# Altium schematic sheet [10] - CONNECTORs.SchDoc(CONNECTORS)
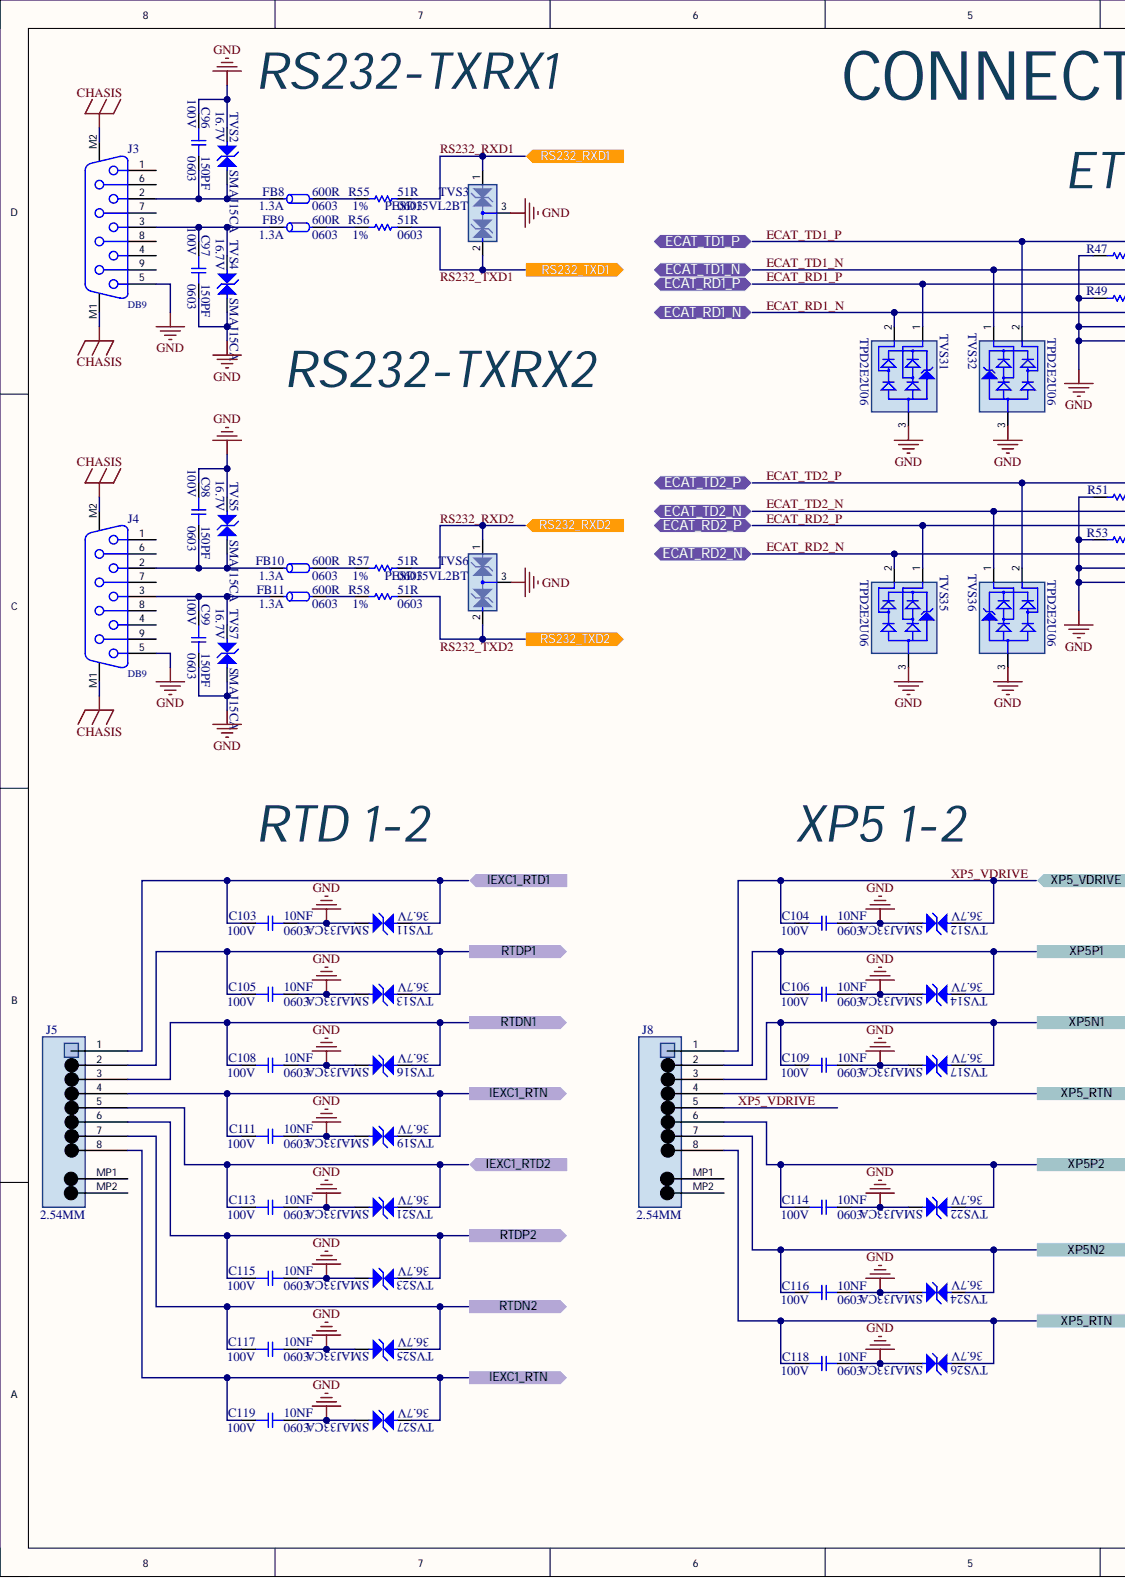
[diagram p0: [10] - CONNECTORs.SchDoc, left]
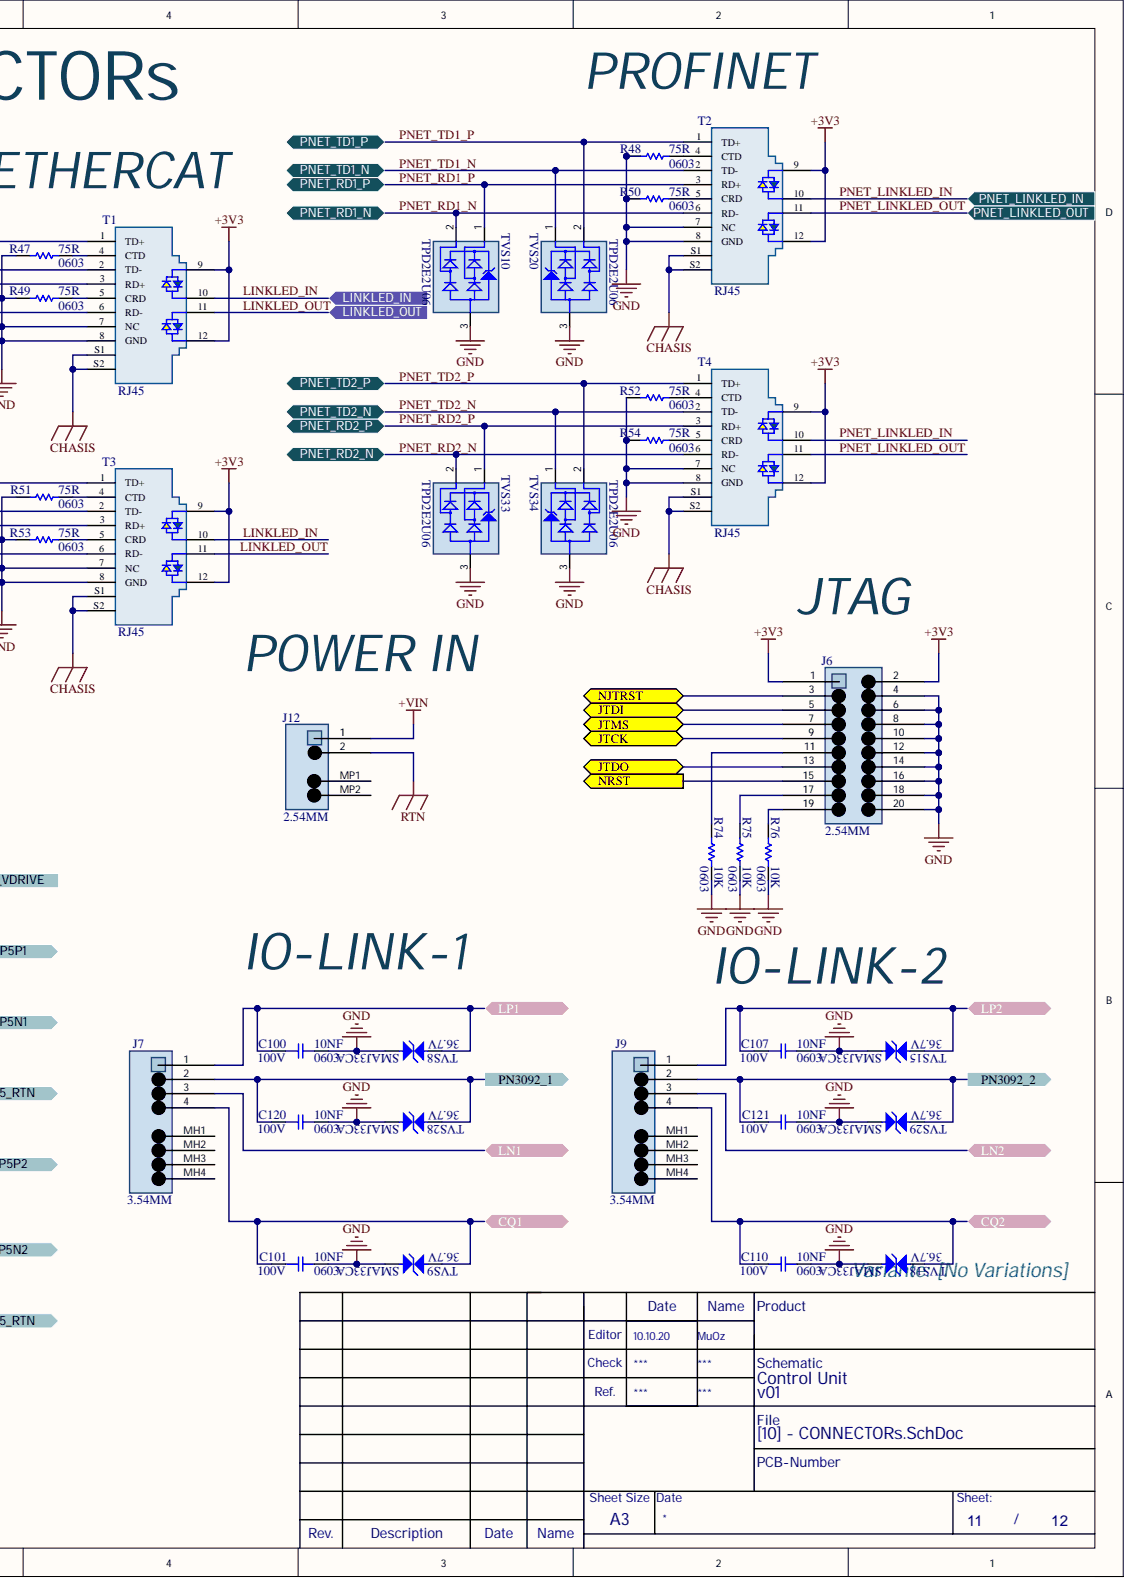
[diagram p1: [10] - CONNECTORs.SchDoc, right]

## Parts
C96  150PF  Case Code (Imperial): 0603  Voltage Rating: 100V  [C-0603]
C97  150PF  Case Code (Imperial): 0603  Voltage Rating: 100V  [C-0603]
C98  150PF  Case Code (Imperial): 0603  Voltage Rating: 100V  [C-0603]
C99  150PF  Case Code (Imperial): 0603  Voltage Rating: 100V  [C-0603]
C100  10NF  Case Code (Imperial): 0603  Voltage Rating: 100V  [C-0603]
C101  10NF  Case Code (Imperial): 0603  Voltage Rating: 100V  [C-0603]
C103  10NF  Case Code (Imperial): 0603  Voltage Rating: 100V  [C-0603]
C104  10NF  Case Code (Imperial): 0603  Voltage Rating: 100V  [C-0603]
C105  10NF  Case Code (Imperial): 0603  Voltage Rating: 100V  [C-0603]
C106  10NF  Case Code (Imperial): 0603  Voltage Rating: 100V  [C-0603]
C107  10NF  Case Code (Imperial): 0603  Voltage Rating: 100V  [C-0603]
C108  10NF  Case Code (Imperial): 0603  Voltage Rating: 100V  [C-0603]
C109  10NF  Case Code (Imperial): 0603  Voltage Rating: 100V  [C-0603]
C110  10NF  Case Code (Imperial): 0603  Voltage Rating: 100V  [C-0603]
C111  10NF  Case Code (Imperial): 0603  Voltage Rating: 100V  [C-0603]
C113  10NF  Case Code (Imperial): 0603  Voltage Rating: 100V  [C-0603]
C114  10NF  Case Code (Imperial): 0603  Voltage Rating: 100V  [C-0603]
C115  10NF  Case Code (Imperial): 0603  Voltage Rating: 100V  [C-0603]
C116  10NF  Case Code (Imperial): 0603  Voltage Rating: 100V  [C-0603]
C117  10NF  Case Code (Imperial): 0603  Voltage Rating: 100V  [C-0603]
C118  10NF  Case Code (Imperial): 0603  Voltage Rating: 100V  [C-0603]
C119  10NF  Case Code (Imperial): 0603  Voltage Rating: 100V  [C-0603]
C120  10NF  Case Code (Imperial): 0603  Voltage Rating: 100V  [C-0603]
C121  10NF  Case Code (Imperial): 0603  Voltage Rating: 100V  [C-0603]
FB8  600R  Case Code (Imperial): 0603  Current Rating: 1.3A  [FB-0603]
FB9  600R  Case Code (Imperial): 0603  Current Rating: 1.3A  [FB-0603]
FB10  600R  Case Code (Imperial): 0603  Current Rating: 1.3A  [FB-0603]
FB11  600R  Case Code (Imperial): 0603  Current Rating: 1.3A  [FB-0603]
J3  DB9  [CON-DB9-618009211821]
J4  DB9  [CON-DB9-618009211821]
J5  2.54MM  [CON-HARTING-8POS-2.54MM]
J6  2.54MM  [CON-SWD-20 POSITION]
J7  3.54MM  [CON-4PIN-IOLINK]
J8  2.54MM  [CON-HARTING-8POS-2.54MM]
J9  3.54MM  [CON-4PIN-IOLINK]
J12  2.54MM  [CON-HARTING-2POS-2.54MM]
R47  75R  Case Code (Imperial): 0603  [R-0603]
R48  75R  Case Code (Imperial): 0603  [R-0603]
R49  75R  Case Code (Imperial): 0603  [R-0603]
R50  75R  Case Code (Imperial): 0603  [R-0603]
R51  75R  Case Code (Imperial): 0603  [R-0603]
R52  75R  Case Code (Imperial): 0603  [R-0603]
R53  75R  Case Code (Imperial): 0603  [R-0603]
R54  75R  Case Code (Imperial): 0603  [R-0603]
R55  51R  Case Code (Imperial): 0603  Tolerance: 1%  [R-0603]
R56  51R  Case Code (Imperial): 0603  Tolerance: 1%  [R-0603]
R57  51R  Case Code (Imperial): 0603  Tolerance: 1%  [R-0603]
R58  51R  Case Code (Imperial): 0603  Tolerance: 1%  [R-0603]
R74  10K  Case Code (Imperial): 0603  [R-0603]
R75  10K  Case Code (Imperial): 0603  [R-0603]
R76  10K  Case Code (Imperial): 0603  [R-0603]
T1  RJ45  [CON-RJ45-74980104400]
T2  RJ45  [CON-RJ45-74980104400]
T3  RJ45  [CON-RJ45-74980104400]
T4  RJ45  [CON-RJ45-74980104400]
TVS2  SMAJ15CA  Breakdown Voltage: 16.7V  [D-SMA-DO214AC-CA]
TVS3  PESD15VL2BT  [SOT23-3]
TVS4  SMAJ15CA  Breakdown Voltage: 16.7V  [D-SMA-DO214AC-CA]
TVS5  SMAJ15CA  Breakdown Voltage: 16.7V  [D-SMA-DO214AC-CA]
TVS6  PESD15VL2BT  [SOT23-3]
TVS7  SMAJ15CA  Breakdown Voltage: 16.7V  [D-SMA-DO214AC-CA]
TVS8  SMAJ33CA  Breakdown Voltage: 36.7V  [D-SMA-DO214AC-CA]
TVS9  SMAJ33CA  Breakdown Voltage: 36.7V  [D-SMA-DO214AC-CA]
TVS10  TPD2E2U06  [SOT323-3]
TVS11  SMAJ33CA  Breakdown Voltage: 36.7V  [D-SMA-DO214AC-CA]
TVS12  SMAJ33CA  Breakdown Voltage: 36.7V  [D-SMA-DO214AC-CA]
TVS13  SMAJ33CA  Breakdown Voltage: 36.7V  [D-SMA-DO214AC-CA]
TVS14  SMAJ33CA  Breakdown Voltage: 36.7V  [D-SMA-DO214AC-CA]
TVS15  SMAJ33CA  Breakdown Voltage: 36.7V  [D-SMA-DO214AC-CA]
TVS16  SMAJ33CA  Breakdown Voltage: 36.7V  [D-SMA-DO214AC-CA]
TVS17  SMAJ33CA  Breakdown Voltage: 36.7V  [D-SMA-DO214AC-CA]
TVS18  SMAJ33CA  Breakdown Voltage: 36.7V  [D-SMA-DO214AC-CA]
TVS19  SMAJ33CA  Breakdown Voltage: 36.7V  [D-SMA-DO214AC-CA]
TVS20  TPD2E2U06  [SOT323-3]
TVS21  SMAJ33CA  Breakdown Voltage: 36.7V  [D-SMA-DO214AC-CA]
TVS22  SMAJ33CA  Breakdown Voltage: 36.7V  [D-SMA-DO214AC-CA]
TVS23  SMAJ33CA  Breakdown Voltage: 36.7V  [D-SMA-DO214AC-CA]
TVS24  SMAJ33CA  Breakdown Voltage: 36.7V  [D-SMA-DO214AC-CA]
TVS25  SMAJ33CA  Breakdown Voltage: 36.7V  [D-SMA-DO214AC-CA]
TVS26  SMAJ33CA  Breakdown Voltage: 36.7V  [D-SMA-DO214AC-CA]
TVS27  SMAJ33CA  Breakdown Voltage: 36.7V  [D-SMA-DO214AC-CA]
TVS28  SMAJ33CA  Breakdown Voltage: 36.7V  [D-SMA-DO214AC-CA]
TVS29  SMAJ33CA  Breakdown Voltage: 36.7V  [D-SMA-DO214AC-CA]
TVS31  TPD2E2U06  [SOT323-3]
TVS32  TPD2E2U06  [SOT323-3]
TVS33  TPD2E2U06  [SOT323-3]
TVS34  TPD2E2U06  [SOT323-3]
TVS35  TPD2E2U06  [SOT323-3]
TVS36  TPD2E2U06  [SOT323-3]

## Ports
CQ1, CQ2, ECAT_RD1_N, ECAT_RD1_P, ECAT_RD2_N, ECAT_RD2_P, ECAT_TD1_N, ECAT_TD1_P, ECAT_TD2_N, ECAT_TD2_P, IEXC1_RTD1, IEXC1_RTD2, IEXC1_RTN, JTCK, JTDI, JTDO, JTMS, LINKLED_IN, LINKLED_OUT, LN1, LN2, LP1, LP2, NJTRST, NRST, PN3092_1, PN3092_2, PNET_LINKLED_IN, PNET_LINKLED_OUT, PNET_RD1_N, PNET_RD1_P, PNET_RD2_N, PNET_RD2_P, PNET_TD1_N, PNET_TD1_P, PNET_TD2_N, PNET_TD2_P, RS232_RXD1, RS232_RXD2, RS232_TXD1, RS232_TXD2, RTDN1, RTDN2, RTDP1, RTDP2, XP5_RTN, XP5_VDRIVE, XP5N1, XP5N2, XP5P1, XP5P2

## Nets
+3V3: J6.1 J6.2 T1.9 T1.12 T2.9 T2.12 T3.9 T3.12 T4.9 T4.12
+VIN: J12.1
CHASIS: J3.M1 J3.M2 J4.M1 J4.M2 T1.S1 T1.S2 T2.S1 T2.S2 T3.S1 T3.S2 T4.S1 T4.S2
GND: C96.1 C97.2 C98.1 C99.2 C100.2 C101.2 C103.2 C104.2 C105.2 C106.2 C107.2 C108.2 C109.2 C110.2 C111.2 C113.2 C114.2 C115.2 C116.2 C117.2 C118.2 C119.2 C120.2 C121.2 J3.5 J4.5 J6.4 J6.6 J6.8 J6.10 J6.12 J6.14 J6.16 J6.18 J6.20 R47.1 R48.1 R49.1 R50.1 R51.1 R52.1 R53.1 R54.1 R74.2 R75.2 R76.2 T1.7(NC) T1.8(GND) T2.7(NC) T2.8(GND) T3.7(NC) T3.8(GND) T4.7(NC) T4.8(GND) TVS2.A TVS3.3 TVS4.C TVS5.A TVS6.3 TVS7.C TVS8.C TVS9.C TVS10.3 TVS11.C TVS12.C TVS13.C TVS14.C TVS15.C TVS16.C TVS17.C TVS18.C TVS19.C TVS20.3 TVS21.C TVS22.C TVS23.C TVS24.C TVS25.C TVS26.C TVS27.C TVS28.C TVS29.C TVS31.3 TVS32.3 TVS33.3 TVS34.3 TVS35.3 TVS36.3
RTN: J12.2
CQ1: C101.1 J7.4 TVS9.A ; port CQ1
CQ2: C110.1 J9.4 TVS18.A ; port CQ2
ECAT_RD1_N: T1.6(RD-) TVS31.2 ; port ECAT_RD1_N
ECAT_RD1_P: T1.3(RD+) TVS31.1 ; port ECAT_RD1_P
ECAT_RD2_N: T3.6(RD-) TVS35.2 ; port ECAT_RD2_N
ECAT_RD2_P: T3.3(RD+) TVS35.1 ; port ECAT_RD2_P
ECAT_TD1_N: T1.2(TD-) TVS32.1 ; port ECAT_TD1_N
ECAT_TD1_P: T1.1(TD+) TVS32.2 ; port ECAT_TD1_P
ECAT_TD2_N: T3.2(TD-) TVS36.1 ; port ECAT_TD2_N
ECAT_TD2_P: T3.1(TD+) TVS36.2 ; port ECAT_TD2_P
IEXC1_RTD1: C103.1 J5.1 TVS11.A ; port IEXC1_RTD1
IEXC1_RTD2: C113.1 J5.5 TVS21.A ; port IEXC1_RTD2
IEXC1_RTN: C111.1 C119.1 J5.4 J5.8 TVS19.A TVS27.A ; port IEXC1_RTN
JTCK: J6.9 ; port JTCK
JTDI: J6.5 ; port JTDI
JTDO: J6.13 ; port JTDO
JTMS: J6.7 ; port JTMS
LINKLED_IN: T1.10 T3.10 ; port LINKLED_IN
LINKLED_OUT: T1.11 T3.11 ; port LINKLED_OUT
LN1: J7.3 ; port LN1
LN2: J9.3 ; port LN2
LP1: C100.1 J7.1 TVS8.A ; port LP1
LP2: C107.1 J9.1 TVS15.A ; port LP2
NJTRST: J6.3 ; port NJTRST
NRST: J6.15 ; port NRST
PN3092_1: C120.1 J7.2 TVS28.A ; port PN3092_1
PN3092_2: C121.1 J9.2 TVS29.A ; port PN3092_2
PNET_LINKLED_IN: T2.10 T4.10 ; port PNET_LINKLED_IN
PNET_LINKLED_OUT: T2.11 T4.11 ; port PNET_LINKLED_OUT
PNET_RD1_N: T2.6(RD-) TVS10.2 ; port PNET_RD1_N
PNET_RD1_P: T2.3(RD+) TVS10.1 ; port PNET_RD1_P
PNET_RD2_N: T4.6(RD-) TVS33.2 ; port PNET_RD2_N
PNET_RD2_P: T4.3(RD+) TVS33.1 ; port PNET_RD2_P
PNET_TD1_N: T2.2(TD-) TVS20.1 ; port PNET_TD1_N
PNET_TD1_P: T2.1(TD+) TVS20.2 ; port PNET_TD1_P
PNET_TD2_N: T4.2(TD-) TVS34.1 ; port PNET_TD2_N
PNET_TD2_P: T4.1(TD+) TVS34.2 ; port PNET_TD2_P
RS232_RXD1: R55.2 TVS3.1 ; port RS232_RXD1
RS232_RXD2: R57.2 TVS6.1 ; port RS232_RXD2
RS232_TXD1: R56.2 TVS3.2 ; port RS232_TXD1
RS232_TXD2: R58.2 TVS6.2 ; port RS232_TXD2
RTDN1: C108.1 J5.3 TVS16.A ; port RTDN1
RTDN2: C117.1 J5.7 TVS25.A ; port RTDN2
RTDP1: C105.1 J5.2 TVS13.A ; port RTDP1
RTDP2: C115.1 J5.6 TVS23.A ; port RTDP2
XP5_RTN: C118.1 J8.4 J8.8 TVS26.A ; port XP5_RTN
XP5_VDRIVE: C104.1 J8.1 J8.5 TVS12.A ; port XP5_VDRIVE
XP5N1: C109.1 J8.3 TVS17.A ; port XP5N1
XP5N2: C116.1 J8.7 TVS24.A ; port XP5N2
XP5P1: C106.1 J8.2 TVS14.A ; port XP5P1
XP5P2: C114.1 J8.6 TVS22.A ; port XP5P2
NetC96_2: C96.2 FB8.1 J3.2 TVS2.C
NetC97_1: C97.1 FB9.1 J3.3 TVS4.A
NetC98_2: C98.2 FB10.1 J4.2 TVS5.C
NetC99_1: C99.1 FB11.1 J4.3 TVS7.A
NetFB8_2: FB8.2 R55.1
NetFB9_2: FB9.2 R56.1
NetFB10_2: FB10.2 R57.1
NetFB11_2: FB11.2 R58.1
NetJ6_11: J6.11 R74.1
NetJ6_17: J6.17 R75.1
NetJ6_19: J6.19 R76.1
NetR47_2: R47.2 T1.4(CTD)
NetR48_2: R48.2 T2.4(CTD)
NetR49_2: R49.2 T1.5(CRD)
NetR50_2: R50.2 T2.5(CRD)
NetR51_2: R51.2 T3.4(CTD)
NetR52_2: R52.2 T4.4(CTD)
NetR53_2: R53.2 T3.5(CRD)
NetR54_2: R54.2 T4.5(CRD)

Not connected: J3 1 4 6 7 8 9; J4 1 4 6 7 8 9; J5 MP1 MP2; J7 MH1 MH2 MH3 MH4; J8 MP1 MP2; J9 MH1 MH2 MH3 MH4; J12 MP1 MP2

## Library data of the parts
C96-C99 = C-150PF-0603: CAP CER 150PF 100V C0G/NP0 0603; Manufacturer: KEMET; Manufacturer Part Number: C0603C151J1GACTU; .Creator: M.OZKAN; .PCBCHK: USED; Dielectric: C0G; Dielectric Material: Ceramic; Temperature Coefficient: 30ppm/°C; Tolerance: 5%; Washability: OK; .SCHCHK: USED; Capacitance: 150pF; Case Code (Metric): 1608; Case/Package: 0603; Component Kind: Standard; ComponentLink1Description: https://datasheet.ciiva.com/5421/kem-c1003-c0g-smd-5421417.pdf?src-supplier=Mouser; ComponentLink1URL: https://datasheet.ciiva.com/5421/kem-c1003-c0g-smd-5421417.pdf?src-supplier=Mouser; ComponentLink2Description: https://datasheet.ciiva.com/7823/40889-7823630.pdf?src-supplier=Element14; ComponentLink2URL: https://datasheet.ciiva.com/7823/40889-7823630.pdf?src-supplier=Element14; Depth: 0.8mm; Height: 0.8mm; Lead Free: Lead Free; Lead Pitch: 0.7mm; Length: 1.6mm; Max Operating Temperature: 125°C; Min Operating Temperature: -55°C; Mount: Surface Mount; Number of Pins: 2; Package Quantity: 4000; Packaging: Tape and Reel; Pricing: 1=0.10000, 10=0.07000, 100=0.03100, 500=0.02214, 1000=0.01739 (USD); Radiation Hardening: No; REACH SVHC: No SVHC; RoHS: Yes; Stock 1: 40687; Supplier 1: Digi-Key; Supplier Part Number 1: 399-9007-1-ND; Termination: SMD/SMT; Thickness: 0.034inch; Voltage Rating (DC): 100V; Width: 0.031inch; Library Name: BARKO_SCHLIB.SchLib
C100 C101 C103-C111 C113-C121 = C-10NF-0603: CAP CER 10000PF 100V X7R 0603; Manufacturer: TDK; Manufacturer Part Number: C1608X7R2A103M080AA; .Creator: M.OZKAN; .PCBCHK: USED; Dielectric Material: Ceramic; Tolerance: 20%; Washability: OK; .SCHCHK: USED; Capacitance: 10nF; Case Code (Metric): 1608; Case/Package: 0603; Component Kind: Standard; ComponentLink1Description: http://www.mouser.com/ds/2/400/lcc_commercial_general_en-837201.pdf; ComponentLink1URL: http://www.mouser.com/ds/2/400/lcc_commercial_general_en-837201.pdf; ComponentLink2Description: http://www.farnell.com/datasheets/1484596.pdf; ComponentLink2URL: http://www.farnell.com/datasheets/1484596.pdf; ComponentLink3Description: https://www.verical.com/server-webapp/html/getDatasheet?partID=126825; ComponentLink3URL: https://www.verical.com/server-webapp/html/getDatasheet?partID=126825; ComponentLink4Description: http://www.avx.com/docs/Catalogs/cauto.pdf; ComponentLink4URL: http://www.avx.com/docs/Catalogs/cauto.pdf; ComponentLink5Description: http://www.mouser.com/ds/2/40/AutoMLCC-777028.pdf; ComponentLink5URL: http://www.mouser.com/ds/2/40/AutoMLCC-777028.pdf; Composition: Ceramic; Depth: 0.8mm; Dielectric: X7R; Features: Soft Termination; Height: 0.8mm; Lead Free: Lead Free; Length: 1.6mm; Max Operating Temperature: 125°C; Min Operating Temperature: -55°C; Mount: Surface Mount; Number of Pins: 2; Package Quantity: 1; Packaging: Tape and Reel; Pricing 1: 1=0.11, 10=0.079, 100=0.0352, 500=0.02516, 1000=0.01977 (USD); Pricing 2: 1=0.1, 10=0.066, 100=0.0293, 500=0.02092, 1000=0.01643 (USD); Pricing 3: 1=0.13, 10=0.099, 100=0.0439, 500=0.03138, 1000=0.02465, 2500=0.02241, 5000=0.02062 (USD); Radiation Hardening: No; Ratings: AEC-Q200; REACH SVHC: No SVHC; Stock 1: 40000; Stock 2: 40000; Stock 3: 89508; Supplier 1: Digi-Key; Supplier 2: Digi-Key; Supplier 3: Digi-Key; Supplier Part Number 1: 445-1305-6-ND; Supplier Part Number 2: 1276-6556-6-ND; Supplier Part Number 3: 478-10798-6-ND; Termination: SMD/SMT; Thickness: 0.037inch; Voltage Rating (DC): 100V; Weight: 7.1E-05oz; Width: 0.031inch; Library Name: BARKO_SCHLIB.SchLib
FB8-FB11 = FB-600R-0603_1: FERRITE BEAD 600 OHM 0603 1LN; Manufacturer: Murata; Manufacturer Part Number: BLM18KG601SN1D; .Creator: F:TALI; .PCBCHK: USED; DC Resistance (DCR): 150mR; Material: Ferrite,Steel; Number of Circuits: 1; Test Frequency: 100MHz; Tolerance: 25%; Washability: OK; .SCHCHK: USED; Case Code (Metric): 1608; Case/Package: 0603; Component Kind: Standard; ComponentLink1Description: https://www.verical.com/server-webapp/html/getDatasheet?partID=226848; ComponentLink1URL: https://www.verical.com/server-webapp/html/getDatasheet?partID=226848; ComponentLink2Description: http://www.murata.com/products/catalog/pdf/c31e.pdf; ComponentLink2URL: http://www.murata.com/products/catalog/pdf/c31e.pdf; ComponentLink3Description: http://www.farnell.com/datasheets/486545.pdf; ComponentLink3URL: http://www.farnell.com/datasheets/486545.pdf; ComponentLink4Description: https://media.digikey.com/pdf/Data%20Sheets/Murata%20PDFs/BLM18yyyyyyN1x.pdf; ComponentLink4URL: https://media.digikey.com/pdf/Data%20Sheets/Murata%20PDFs/BLM18yyyyyyN1x.pdf; ComponentLink5Description: http://uk.rs-online.com/webdocs/13ed/0900766b813ed050.pdf; ComponentLink5URL: http://uk.rs-online.com/webdocs/13ed/0900766b813ed050.pdf; Core Material: Ferrite; DC Current: 1.3A; Depth: 0.8mm; Height: 0.8mm; Impedance: 600R; Lead Free: Lead Free; Length: 1.6mm; Max Current Rating: 1.3A; Max DC Current: 1.3A; Max Operating Temperature: 125°C; Military Standard: Not; Min Operating Temperature: -55°C; Number of Lines: 1; Number of Pins: 2; Package Quantity: 1; Packaging: Tape and Reel; Pricing 1: 1=0.1, 10=0.079, 25=0.0712, 50=0.0522, 100=0.0451, 250=0.03564, 500=0.0301, 1000=0.02534 (USD); Radiation Hardening: No; REACH SVHC: No SVHC; Stock 1: 578615; Supplier 1: Digi-Key; Supplier Part Number 1: 490-5258-6-ND; Termination: SMD/SMT; Weight: 7.1E-05oz; Width: 0.8mm; Library Name: BARKO_SCHLIB.SchLib
J3 J4 = CON-DB9-FEMALE: WR-DSUB FEMALE ANGLED PCB CONNEC; Manufacturer: Wurth Electronics; Manufacturer Part Number: 618009211821; Component Kind: Standard; ComponentLink1Description: Manufacturer; ComponentLink1URL: http://www.we-online.de; ComponentLink2Description: Datasheet; ComponentLink2URL: https://katalog.we-online.de/em/datasheet/6180xx211821.pdf; Pricing: 1=2.47, 10=2.343, 50=2.081, 100=1.815, 250=1.557, 500=1.387 (USD); RoHS: No; Stock: 78; Supplier 1: Digi-Key; Supplier Part Number 1: 732-618009211821-ND; Library Name: BARKO_SCHLIB.SchLib
J5 J8 = CON-8POS-2.54MM-SMD: TERM BLK 8P SIDE ENT 2.54MM SMD; Manufacturer: HARTING Technology; Manufacturer Part Number: 14010813102000; Current: 6A; Current Rating: 8.4A; Gender: Receptacle; Number of Contacts: 8; Number of Levels: 1; Pitch: 0.1inch; Voltage: 160V; Wire Gauge (Max): 26AWG; Wire Gauge (Min): 20AWG; Color: Black; Component Kind: Standard; ComponentLink1Description: https://datasheet.ciiva.com/48506/harting-1401xx13102000-eng-tds01-48506086.pdf?src-supplier=Distrelec; ComponentLink1URL: https://datasheet.ciiva.com/48506/harting-1401xx13102000-eng-tds01-48506086.pdf?src-supplier=Distrelec; ComponentLink2Description: https://datasheet.ciiva.com/46428/1817031-46428390.pdf?src-supplier=Element14; ComponentLink2URL: https://datasheet.ciiva.com/46428/1817031-46428390.pdf?src-supplier=Element14; ComponentLink3Description: https://datasheet.ciiva.com/49025/70357504-49025163.pdf?src-supplier=Allied+Electronics; ComponentLink3URL: https://datasheet.ciiva.com/49025/70357504-49025163.pdf?src-supplier=Allied+Electronics; Contact Material: Copper; Contact Plating: Tin; Depth: 5mm; Housing Material: Liquid Crystal Polymer; Length: 25.01mm; Library Name: SCHLIB.SchLib; Material: Polymer,Glass fibre; Max Operating Temperature: 125°C; Min Operating Temperature: -40°C; Mount: Surface Mount,Panel; Number of Positions Per Level: 8; Number of Rows: 2; Orientation: Horizontal; Packaging: Tape and Reel; Pricing: 1=2.11, 10=1.913, 100=1.6401 (USD); REACH SVHC: No SVHC; RoHS: Yes; Stock: 484; Supplier 1: Digi-Key; Supplier Part Number 1: 1195-3662-1-ND; Termination: Screwless; Voltage Rating: 160V; Width: 9.2mm
J6 = CON-20POS-2.54MM HEADER: CONN HEADER VERT 20POS 2.54MM; Manufacturer: On Shore Technology Inc.; Manufacturer Part Number: 302-S201; Connector Type: Receptacle; Contact Resistance: 20mR; Current Rating: 3A; Max Voltage Rating (AC): 1kV; Number of Contacts: 20; Row Spacing: 0.1inch; Color: Grey; Component Kind: Standard; ComponentLink1Description: https://datasheet.ciiva.com/9473/89898-9473111.pdf?src-supplier=Avnet; ComponentLink1URL: https://datasheet.ciiva.com/9473/89898-9473111.pdf?src-supplier=Avnet; ComponentLink2Description: https://datasheet.ciiva.com/412/89898-412170.pdf?src-supplier=Digi-Key; ComponentLink2URL: https://datasheet.ciiva.com/412/89898-412170.pdf?src-supplier=Digi-Key; ComponentLink3Description: https://datasheet.ciiva.com/16731/89898-16731623.pdf?src-supplier=Avnet+Europe; ComponentLink3URL: https://datasheet.ciiva.com/16731/89898-16731623.pdf?src-supplier=Avnet+Europe; ComponentLink4Description: https://datasheet.ciiva.com/46428/320508-46428539.pdf?src-supplier=Element14; ComponentLink4URL: https://datasheet.ciiva.com/46428/320508-46428539.pdf?src-supplier=Element14; Contact Material: Bronze; Contact Plating: Gold; Depth: 5.08mm; Gender: Receptacle; Housing Color: Grey; Housing Material: Thermoplastic; Lead Free: Lead Free; Length: 15.16mm; Library Name: SCHLIB.SchLib; Max Operating Temperature: 125°C; Min Operating Temperature: -65°C; Mount: Surface Mount; Number of Positions: 20; Number of Rows: 2; Orientation: Straight; Package Quantity: 650; Packaging: Tape and Reel; Pitch: 2.54mm; Pricing: 1=1.41, 10=1.243, 100=1.0728 (USD); Radiation Hardening: No; REACH SVHC: No SVHC; RoHS: Yes; Stock: 6320; Termination: Solder; Voltage Rating: 1kV
J7 J9 = CON-4POS-IOLINK: CONN PLUG FMALE 4POS GOLD SOLDER; Manufacturer: TE Connectivity; Manufacturer Part Number: T4145515041-001; Connector System: Wire-to-Board; Connector Type: Receptacle,Socket; Mating Retention: Yes (With); Number of Positions: 4; Plating: Nickel; Shielding: Unshielded; Component Kind: Standard; Insulation Material: Polyamide; Library Name: SCHLIB.SchLib; Max Operating Temperature: 85°C; Min Operating Temperature: -40°C; Mount: Panel; Number of Signal Positions: 4; Pricing: 1=7.15, 10=6.854, 25=6.258, 50=5.96, 100=5.662, 250=5.066, 500=4.768, 1000=4.172, 2500=3.9634 (USD); RoHS: No; Sealable: Yes (With); Shell Material: Brass; Stock: 398; Supplier 1: Digi-Key; Supplier Part Number 1: A126539-ND
J12 = CON-2POS-2.54MM-SMD: TERM BLK 2P SIDE ENT 2.54MM SMD; Manufacturer: HARTING Technology; Manufacturer Part Number: 14010213102000; Current: 6A; Current Rating: 8.4A; Gender: Receptacle; Number of Contacts: 2; Number of Levels: 1; Pitch: 0.1inch; Voltage: 160V; Wire Gauge (Max): 26AWG; Wire Gauge (Min): 20AWG; Color: Black; Component Kind: Standard; ComponentLink1Description: https://datasheet.ciiva.com/46428/1817031-46428378.pdf?src-supplier=Element14; ComponentLink1URL: https://datasheet.ciiva.com/46428/1817031-46428378.pdf?src-supplier=Element14; Contact Gender: Female; Contact Material: Copper; Contact Plating: Tin; Depth: 5mm; Housing Material: Polymer; Length: 9.77mm; Library Name: SCHLIB.SchLib; Material: Polymer,Glass fibre; Max Operating Temperature: 125°C; Min Operating Temperature: -40°C; Mount: Surface Mount; Number of Poles: 2; Number of Positions Per Level: 2; Number of Rows: 2; Orientation: Horizontal; Packaging: Tape and Reel; Pricing: 1=1.05, 10=0.926, 100=0.7986 (USD); REACH SVHC: No SVHC; RoHS: Yes; Stock: 967; Supplier 1: Digi-Key; Supplier Part Number 1: 1195-3656-1-ND; Termination: Screwless; Voltage Rating: 160V; Width: 9.2mm
R47-R54 = R-75R-0603: RES SMD 75 OHM 1% 1/10W 0603; Manufacturer: Stackpole Electronics; Manufacturer Part Number: RMCF0603FT75R0; .Creator: M.OZKAN; .PCBCHK: USED; Max Power Dissipation: 100mW; Temperature Coefficient: 100ppm/°C; Washability: OK; .SCHCHK: USED; Case Code (Metric): 1608; Case/Package: 0603; Component Kind: Standard; ComponentLink1Description: https://datasheet.ciiva.com/15193/getdatasheetpartid-701591-15193493.pdf?src-supplier=Verical; ComponentLink1URL: https://datasheet.ciiva.com/15193/getdatasheetpartid-701591-15193493.pdf?src-supplier=Verical; Composition: Thick Film; Contact Plating: Tin,Matte; Depth: 0.8mm; Features: Automotive AEC-Q200; Height: 0.022inch; Length: 0.061inch; Max Operating Temperature: 155°C; Military Standard: Not; Min Operating Temperature: -55°C; Mount: Surface Mount; Number of Terminations: 2; Packaging: Tape and Reel; Pricing 1: 1=0,10000, 10=0,01600, 100=0,00650, 1000=0,00292, 2500=0,00253 (USD); Radiation Hardening: No; Resistance: 75R; RoHS: Yes; Stock 1: 169664; Supplier 1: Digi-Key; Supplier Part Number 1: RMCF0603FT75R0CT-ND; Tolerance: 1%; Width: 0.031inch; Library Name: BARKO_SCHLIB.SchLib
R55-R58 = R-51R-0603: RES 51 OHM 1% 1/10W 0603; Manufacturer: Stackpole Electronics; Manufacturer Part Number: RMCF0603FT51R0; Max Power Dissipation: 100mW; Temperature Coefficient: 100ppm/°C; Case Code (Metric): 1608; Case/Package: 0603; Component Kind: Standard; ComponentLink1Description: https://www.seielect.com/catalog/SEI-Packaging.pdf?src-supplier=Digi-Key; ComponentLink1URL: https://www.seielect.com/catalog/SEI-Packaging.pdf?src-supplier=Digi-Key; ComponentLink2Description: https://www.seielect.com/catalog/SEI-RMCF_RMCP.pdf?src-supplier=Avnet; ComponentLink2URL: https://www.seielect.com/catalog/SEI-RMCF_RMCP.pdf?src-supplier=Avnet; Composition: Thick Film; Contact Plating: Tin,Matte; Features: Automotive AEC-Q200; Height: 0.022inch; Lead Free: Lead Free; Length: 0.061inch; Max Operating Temperature: 155°C; Min Operating Temperature: -55°C; Mount: Surface Mount; Number of Terminations: 2; Packaging: Tape and Reel; Pricing: 1=0.1, 10=0.015, 100=0.0063, 1000=0.0028, 2500=0.0024 (USD); Radiation Hardening: No; Resistance: 51R; RoHS: Yes; Stock: 90930; Supplier 1: Digi-Key; Supplier Part Number 1: RMCF0603FT51R0CT-ND; Width: 0.031inch; Library Name: BARKO_SCHLIB.SchLib
R74-R76 = R-10K-0603: RES SMD 10K OHM 1% 1/10W 0603; Manufacturer: Yageo; Manufacturer Part Number: RC0603FR-0710KL; .Creator: F:TALI; .PCBCHK: USED; Max Power Dissipation: 100mW; Resistance: 10kR; Temperature Coefficient: 100ppm/°C; Voltage Rating: 75V; Voltage Rating (DC): 50V; Washability: OK; .SCHCHK: USED; Case Code (Metric): 1608; Case/Package: 0603; Component Kind: Standard; ComponentLink1Description: http://www.farnell.com/datasheets/38590.pdf; ComponentLink1URL: http://www.farnell.com/datasheets/38590.pdf; ComponentLink2Description: https://www.verical.com/server-webapp/html/getDatasheet?partID=409289; ComponentLink2URL: https://www.verical.com/server-webapp/html/getDatasheet?partID=409289; ComponentLink3Description: http://www.farnell.com/datasheets/1868787.pdf; ComponentLink3URL: http://www.farnell.com/datasheets/1868787.pdf; ComponentLink4Description: http://www.farnell.com/datasheets/443971.pdf; ComponentLink4URL: http://www.farnell.com/datasheets/443971.pdf; ComponentLink5Description: http://www.farnell.com/datasheets/1738695.pdf; ComponentLink5URL: http://www.farnell.com/datasheets/1738695.pdf; ComponentLink6Description: http://www.yageo.com/documents/recent/PYu-RC_Group_51_RoHS_L_9.pdf; ComponentLink6URL: http://www.yageo.com/documents/recent/PYu-RC_Group_51_RoHS_L_9.pdf; ComponentLink7Description: https://www.verical.com/server-webapp/html/getDatasheet?partID=327958; ComponentLink7URL: https://www.verical.com/server-webapp/html/getDatasheet?partID=327958; ComponentLink8Description: http://www.yageo.com/documents/recent/PYu-RC_Group_51_RoHS_L_2.pdf; ComponentLink8URL: http://www.yageo.com/documents/recent/PYu-RC_Group_51_RoHS_L_2.pdf; ComponentLink9Description: http://www.farnell.com/datasheets/1678796.pdf; ComponentLink9URL: http://www.farnell.com/datasheets/1678796.pdf; ComponentLink10Description: http://industrial.panasonic.com/www-cgi/jvcr13pz.cgi?E+PZ+3+AOA0002+ERJ1GNJ120C+7+WW; ComponentLink10URL: http://industrial.panasonic.com/www-cgi/jvcr13pz.cgi?E+PZ+3+AOA0002+ERJ1GNJ120C+7+WW; ComponentLink11Description: http://industrial.panasonic.com/www-data/pdf/AOA0000/AOA0000CE1.pdf; ComponentLink11URL: http://industrial.panasonic.com/www-data/pdf/AOA0000/AOA0000CE1.pdf; ComponentLink12Description: http://www.jameco.com/Jameco/Products/ProdDS/2007265.pdf; ComponentLink12URL: http://www.jameco.com/Jameco/Products/ProdDS/2007265.pdf; ComponentLink13Description: https://industrial.panasonic.com/cdbs/www-data/pdf/RDA0000/AOA0000C301.pdf; ComponentLink13URL: https://industrial.panasonic.com/cdbs/www-data/pdf/RDA0000/AOA0000C301.pdf; Composition: Thick Film; Contact Plating: Matte,Tin; Depth: 0.8mm; Features: Automotive AEC-Q200; Height: 0.45mm; Lead Free: Lead Free; Lead Pitch: 0.3mm; Length: 1.6mm; Max Operating Temperature: 155°C; Military Standard: Not; Min Operating Temperature: -55°C; Mount: Surface Mount; Number of Pins: 2; Number of Terminations: 2; Package Quantity: 1; Packaging: Tape and Reel; Power Rating: 100mW; Pricing 1: 1=0.1, 10=0.026, 100=0.0107, 1000=0.00478, 2500=0.00415 (USD); Pricing 2: 1=0.1, 10=0.022, 100=0.0088, 1000=0.00396, 2500=0.00344 (USD); Pricing 3: 1=0.1, 10=0.044, 100=0.0178, 1000=0.00798, 2500=0.00693 (USD); Radiation Hardening: No; REACH SVHC: No SVHC; Stock 1: 37479107; Stock 2: 34952003; Stock 3: 0; Supplier 1: Digi-Key; Supplier 2: Digi-Key; Supplier 3: Digi-Key; Supplier Part Number 1: 311-10.0KHRCT-ND; Supplier Part Number 2: 311-10KGRDKR-ND; Supplier Part Number 3: YAG1290DKR-ND; Termination: SMD/SMT; Tolerance: 1%; Weight: 7.1E-05oz; Width: 0.8mm; Working Voltage: 75V; Library Name: BARKO_SCHLIB.SchLib
T1-T4 = CON-RJ45-74980104400: CONN JACK 1PORT 100 BASE-T PCB; Manufacturer: Wurth Electronics; Component Kind: Standard; ComponentLink1Description: Manufacturer; ComponentLink1URL: http://www.we-online.de; ComponentLink2Description: Datasheet; ComponentLink2URL: https://www.we-online.com/catalog/datasheet/74980104400.pdf; Library Name: SCHLIB.SchLib; Manufacturer Part Number: 74980104400; Pricing: 1=5.82, 5=5.2, 10=4.78, 50=4.26 (USD); RoHS: No; Stock: 86; Supplier 1: Digi-Key; Supplier Part Number 1: 732-13939-1-ND
TVS2 TVS4 TVS5 TVS7 = TVS-SMAJ15CA: TVS DIODE 15V 24.4V DO214AC; Manufacturer: Littelfuse; Direction: Bidirectional; Element Configuration: Single; Leakage Current: 1uA; Max Breakdown Voltage: 18.5V; Max Power Dissipation: 3.3W; Max Surge Current: 16.4A; Number of Bidirectional Channels: 1; Peak Pulse Power: 400W; Power Line Protection: No; Test Current: 1mA; Clamping Voltage: 24.4V; Component Kind: Standard; ComponentLink1Description: https://datasheet.ciiva.com/25624/0900766b810f4edb-25624840.pdf?src-supplier=RSComponents; ComponentLink1URL: https://datasheet.ciiva.com/25624/0900766b810f4edb-25624840.pdf?src-supplier=RSComponents; ComponentLink2Description: https://datasheet.ciiva.com/331/littelfuse-tvs-diode-smaj-datasheet-pdf-331084.pdf?src-supplier=Digi-Key; ComponentLink2URL: https://datasheet.ciiva.com/331/littelfuse-tvs-diode-smaj-datasheet-pdf-331084.pdf?src-supplier=Digi-Key; ComponentLink3Description: https://datasheet.ciiva.com/28473/607617-28473388.pdf?src-supplier=Element14; ComponentLink3URL: https://datasheet.ciiva.com/28473/607617-28473388.pdf?src-supplier=Element14; ComponentLink4Description: https://datasheet.ciiva.com/331/littelfuse-tvs-diode-smaj-datasheet-pdf-331085.pdf?src-supplier=Digi-Key; ComponentLink4URL: https://datasheet.ciiva.com/331/littelfuse-tvs-diode-smaj-datasheet-pdf-331085.pdf?src-supplier=Digi-Key; ComponentLink5Description: https://datasheet.ciiva.com/331/littelfuse-tvs-diode-smaj-datasheet-pdf-331086.pdf?src-supplier=Digi-Key; ComponentLink5URL: https://datasheet.ciiva.com/331/littelfuse-tvs-diode-smaj-datasheet-pdf-331086.pdf?src-supplier=Digi-Key; ComponentLink6Description: https://datasheet.ciiva.com/16487/littelfuse-tvs-diode-smaj-16487445.pdf?src-supplier=Future+Electronics; ComponentLink6URL: https://datasheet.ciiva.com/16487/littelfuse-tvs-diode-smaj-16487445.pdf?src-supplier=Future+Electronics; ComponentLink7Description: https://datasheet.ciiva.com/8062/littelfuse-tvs-diode-smaj-8062270.pdf?src-supplier=Element14; ComponentLink7URL: https://datasheet.ciiva.com/8062/littelfuse-tvs-diode-smaj-8062270.pdf?src-supplier=Element14; Composition: Zener; Height: 2.087mm; Lead Free: Lead Free; Length: 4.5mm; Manufacturer Part Number: SMAJ15CA; Max Operating Temperature: 150°C; Max Reverse Leakage Current: 1uA; Min Breakdown Voltage: 16.7V; Min Operating Temperature: -65°C; Mount: Surface Mount; Number of Channels: 1; Number of Elements: 1; Number of Pins: 2; Operating Supply Voltage: 15V; Package Quantity: 5000; Packaging: Tape and Reel; Peak Pulse Current: 16.4A; Polarity: Bidirectional; Power Dissipation: 400W; Pricing: 1=0.41, 10=0.275, 100=0.1625, 500=0.11, 1000=0.0825 (USD); Radiation Hardening: No; REACH SVHC: No SVHC; Reverse Breakdown Voltage: 16.7V; Reverse Standoff Voltage: 15V; RoHS: Yes; Stock: 47858; Supplier 1: Digi-Key; Supplier Part Number 1: SMAJ15CALFCT-ND; Termination: SMD/SMT; Voltage Rating (DC): 15V; Width: 2.79mm; Library Name: BARKO_SCHLIB.SchLib
TVS3 TVS6 = TVS-PESD15VL2BT,215: TVS DIODE 15V 44V SOT23; Manufacturer: Nexperia; Component Kind: Standard; ComponentLink1Description: https://assets.nexperia.com/documents/data-sheet/PESDXL2BT_SER.pdf?src-supplier=Digi-Key; ComponentLink1URL: https://assets.nexperia.com/documents/data-sheet/PESDXL2BT_SER.pdf?src-supplier=Digi-Key; Library Name: SCHLIB.SchLib; Manufacturer Part Number: PESD15VL2BT,215; Pricing: 1=0.45, 10=0.308, 100=0.182, 500=0.1232, 1000=0.0924 (USD); RoHS: No; Stock: 63222; Supplier 1: Digi-Key; Supplier Part Number 1: 1727-3813-1-ND
TVS8 TVS9 TVS11-TVS19 TVS21-TVS29 = TVS-SMAJ33CA: TVS DIODE 33V 53.3V DO214AC; Manufacturer: Littelfuse; Direction: Bidirectional; Leakage Current: 1uA; Max Breakdown Voltage: 40.6V; Max Power Dissipation: 3.3W; Max Surge Current: 7.5A; Number of Bidirectional Channels: 1; Peak Pulse Power: 400W; Power Line Protection: No; Test Current: 1mA; Clamping Voltage: 53.3V; Component Kind: Standard; ComponentLink1Description: https://datasheet.ciiva.com/331/littelfuse-tvs-diode-smaj-datasheet-pdf-331111.pdf?src-supplier=Digi-Key; ComponentLink1URL: https://datasheet.ciiva.com/331/littelfuse-tvs-diode-smaj-datasheet-pdf-331111.pdf?src-supplier=Digi-Key; ComponentLink2Description: https://datasheet.ciiva.com/331/littelfuse-tvs-diode-smaj-datasheet-pdf-331112.pdf?src-supplier=Digi-Key; ComponentLink2URL: https://datasheet.ciiva.com/331/littelfuse-tvs-diode-smaj-datasheet-pdf-331112.pdf?src-supplier=Digi-Key; ComponentLink3Description: https://datasheet.ciiva.com/16487/littelfuse-tvs-diode-smaj-16487784.pdf?src-supplier=Future+Electronics; ComponentLink3URL: https://datasheet.ciiva.com/16487/littelfuse-tvs-diode-smaj-16487784.pdf?src-supplier=Future+Electronics; ComponentLink4Description: https://datasheet.ciiva.com/331/littelfuse-tvs-diode-smaj-datasheet-pdf-331113.pdf?src-supplier=Digi-Key; ComponentLink4URL: https://datasheet.ciiva.com/331/littelfuse-tvs-diode-smaj-datasheet-pdf-331113.pdf?src-supplier=Digi-Key; ComponentLink5Description: https://datasheet.ciiva.com/28473/607617-28473417.pdf?src-supplier=Element14; ComponentLink5URL: https://datasheet.ciiva.com/28473/607617-28473417.pdf?src-supplier=Element14; Composition: Zener; Height: 2.29mm; Lead Free: Lead Free; Length: 5.28mm; Manufacturer Part Number: SMAJ33CA; Max Operating Temperature: 150°C; Min Breakdown Voltage: 36.7V; Min Operating Temperature: -65°C; Mount: Surface Mount; Number of Channels: 1; Number of Elements: 1; Number of Pins: 2; Operating Supply Voltage: 33V; Package Quantity: 5000; Packaging: Tape and Reel; Peak Pulse Current: 7.5A; Polarity: Bidirectional; Power Dissipation: 400W; Pricing: 1=0.41, 10=0.275, 100=0.1625, 500=0.11, 1000=0.0825 (USD); REACH SVHC: No SVHC; Reverse Breakdown Voltage: 36.7V; Reverse Standoff Voltage: 33V; RoHS: Yes; Stock: 28291; Supplier 1: Digi-Key; Supplier Part Number 1: SMAJ33CALFCT-ND; Termination: SMD/SMT; Voltage Rating (DC): 33V; Width: 2.79mm; Library Name: BARKO_SCHLIB.SchLib
TVS10 TVS20 TVS31-TVS36 = TVS-TPD2E2U06QDCKRQ1: TVS DIODE 5.5V 12.4V SC70-3; Manufacturer: Texas Instruments; Capacitance: 1.5pF; Interface: LVDS,USB,Ethernet; Number of Channels: 2; Component Kind: Standard; ComponentLink1Description: http://www.ti.com/general/docs/suppproductinfo.tsp?distId=10&amp;gotoUrl=http%3A%2F%2Fwww.ti.com%2Flit%2Fgpn%2Ftpd2e2u06-q1&src-supplier=Digi-Key; ComponentLink1URL: http://www.ti.com/general/docs/suppproductinfo.tsp?distId=10&amp;gotoUrl=http%3A%2F%2Fwww.ti.com%2Flit%2Fgpn%2Ftpd2e2u06-q1&src-supplier=Digi-Key; ComponentLink2Description: http://www.ti.com/lit/pdf/sszt035?src-supplier=Digi-Key; ComponentLink2URL: http://www.ti.com/lit/pdf/sszt035?src-supplier=Digi-Key; Lead Free: Lead Free; Library Name: SCHLIB.SchLib; Manufacturer Part Number: TPD2E2U06QDCKRQ1; Max Operating Temperature: 125°C; Min Operating Temperature: -40°C; Pricing: 1=0.61, 10=0.49, 100=0.385, 500=0.266, 1000=0.21 (USD); RoHS: No; Stock: 14322; Supplier 1: Digi-Key; Supplier Part Number 1: 296-44302-1-ND
